# Altium schematic sheet RaumluftsensorC3.SchDoc(RaumluftsensorC3)
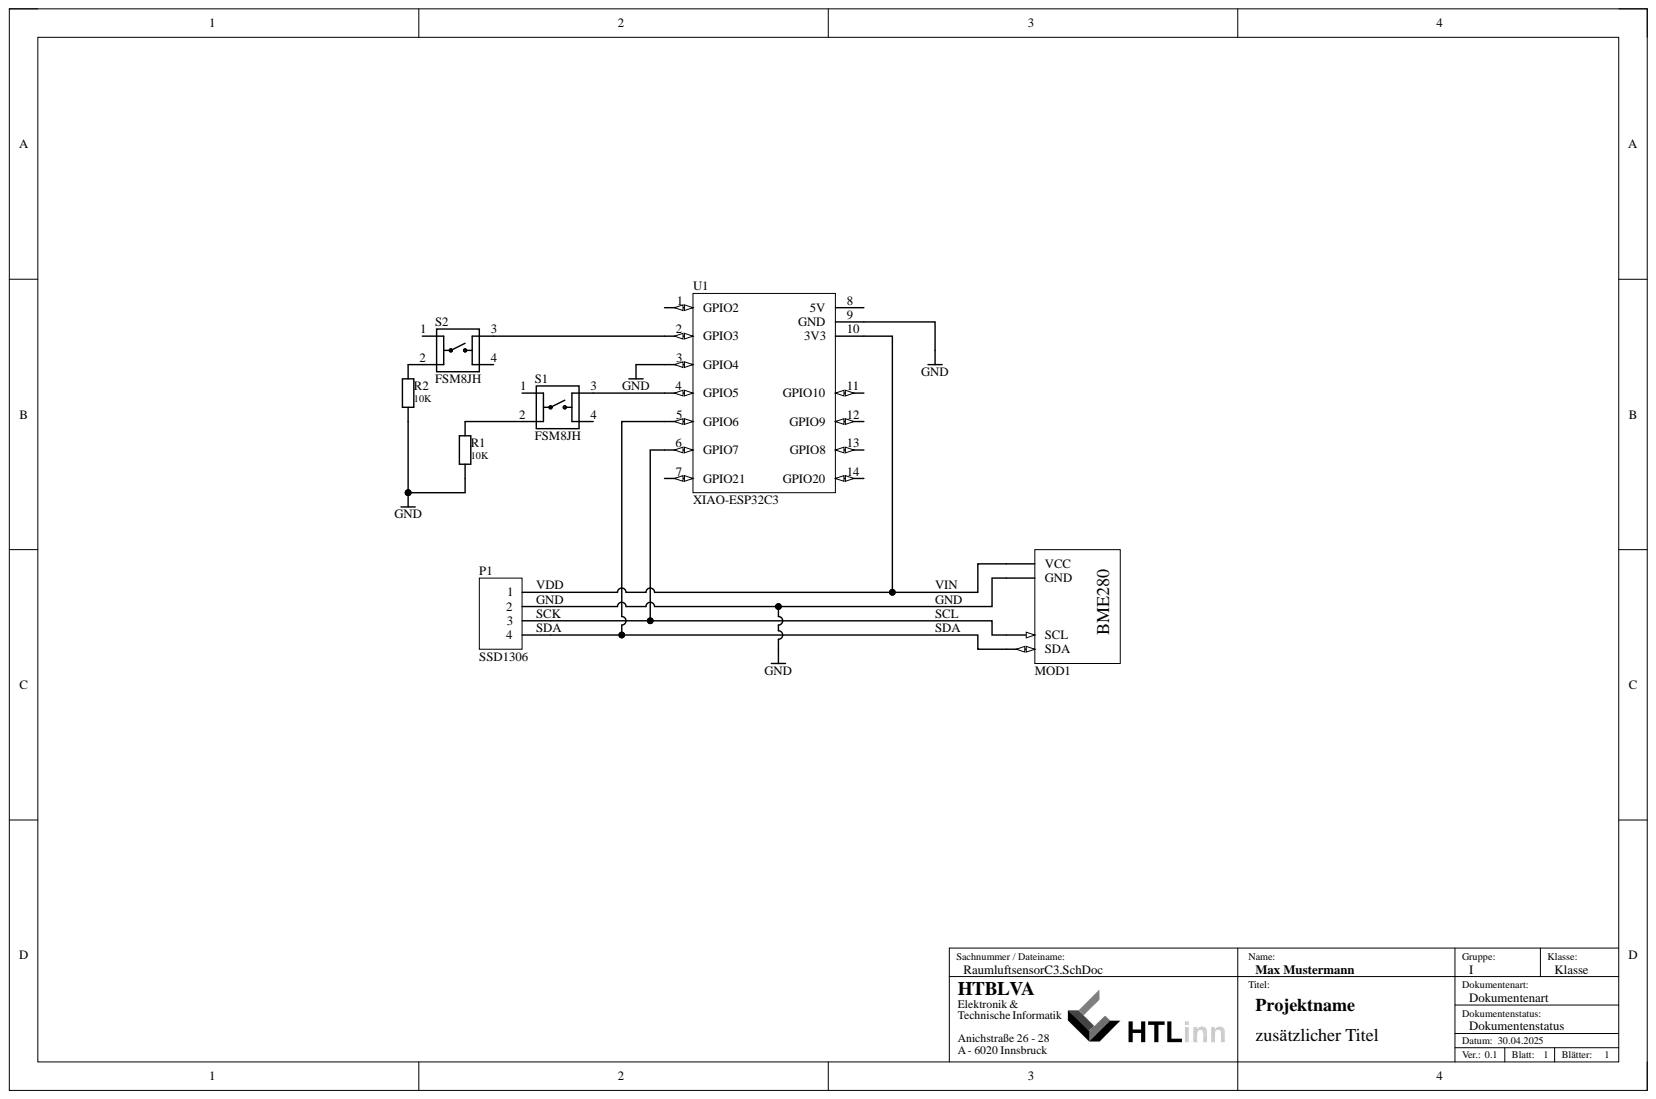
[diagram p0: RaumluftsensorC3.SchDoc]

## Parts
MOD1  BME280  [BME280]
P1  SSD1306  [HDR1X4]
R1  10K  [R_0207/RM4]
R2  10K  [R_0207/RM4]
S1  FSM8JH  [SW_FSM8JH]
S2  FSM8JH  [SW_FSM8JH]
U1  XIAO-ESP32C3  [PCBComponent_1]

## Nets
GND: MOD1.2(GND) P1.2 R1.1 R2.1 U1.3(GPIO4) U1.9(GND)
NetMOD1_1: MOD1.1(VCC) P1.1 U1.10(3V3)
NetMOD1_3: MOD1.3(SCL) P1.3 U1.6(GPIO7)
NetMOD1_4: MOD1.4(SDA) P1.4 U1.5(GPIO6)
NetR1_2: R1.2 S1.2
NetR2_2: R2.2 S2.2
NetS1_1: S1.1
NetS1_3: S1.3 U1.4(GPIO5)
NetS1_4: S1.4
NetS2_1: S2.1
NetS2_3: S2.3 U1.2(GPIO3)
NetS2_4: S2.4
NetU1_1: U1.1(GPIO2)
NetU1_7: U1.7(GPIO21)
NetU1_8: U1.8(5V)
NetU1_11: U1.11(GPIO10)
NetU1_12: U1.12(GPIO9)
NetU1_13: U1.13(GPIO8)
NetU1_14: U1.14(GPIO20)

## Library data of the parts
MOD1 = BME280: Bosch BME280 Module GY-BME280-3.3; Usage: only permitted for non-commercial purposes at HTL I Innsbruck; Component Kind: Standard; LatestRevisionDate: 06-Jan-2018; Published: 06-Jan-2018; Library Name: HTLinn_Miscellaneous.IntLib; Publisher: Michael Kupfner
P1 = Header 4: OLED SSD1306 - Display; Component Kind: Standard; LatestRevisionDate: 17-Jul-2002; LatestRevisionNote: Re-released for DXP Platform.; Library Name: Miscellaneous Connectors.IntLib; Signal Integrity: Connector; Publisher: Altium Limited
R1 R2 = R: Resistor; Usage: only permitted for non-commercial purposes at HTL I Innsbruck; Component Kind: Standard; LatestRevisionDate: 07-Sep-2019; Library Name: HTLInn_RLCQ.IntLib; Published: 21-Feb-2011; Signal Integrity: R; Simulation: R; Value: 10K
S1 S2 = FSM8JH: Tactile Switch SPST-NO Top Actuated Through Hole; MF: TE Connectivity; Availability: In Stock; Check_prices: https://www.snapeda.com/parts/FSM8JH/TE+Connectivity+ALCOSWITCH+Switches/view-part/?ref=eda; Component Kind: Standard; Library Name: FSM8JH.IntLib; MP: FSM8JH; Package: None; Price: None; SnapEDA_Link: https://www.snapeda.com/parts/FSM8JH/TE+Connectivity+ALCOSWITCH+Switches/view-part/?ref=snap
U1 = XIAO-ESP32C3: Component Kind: Standard; Description: XIAO-ESP32C3; Library Name: XIAO ESP32C3.IntLib
